# Altium schematic sheet Power Amplifier.SchDoc
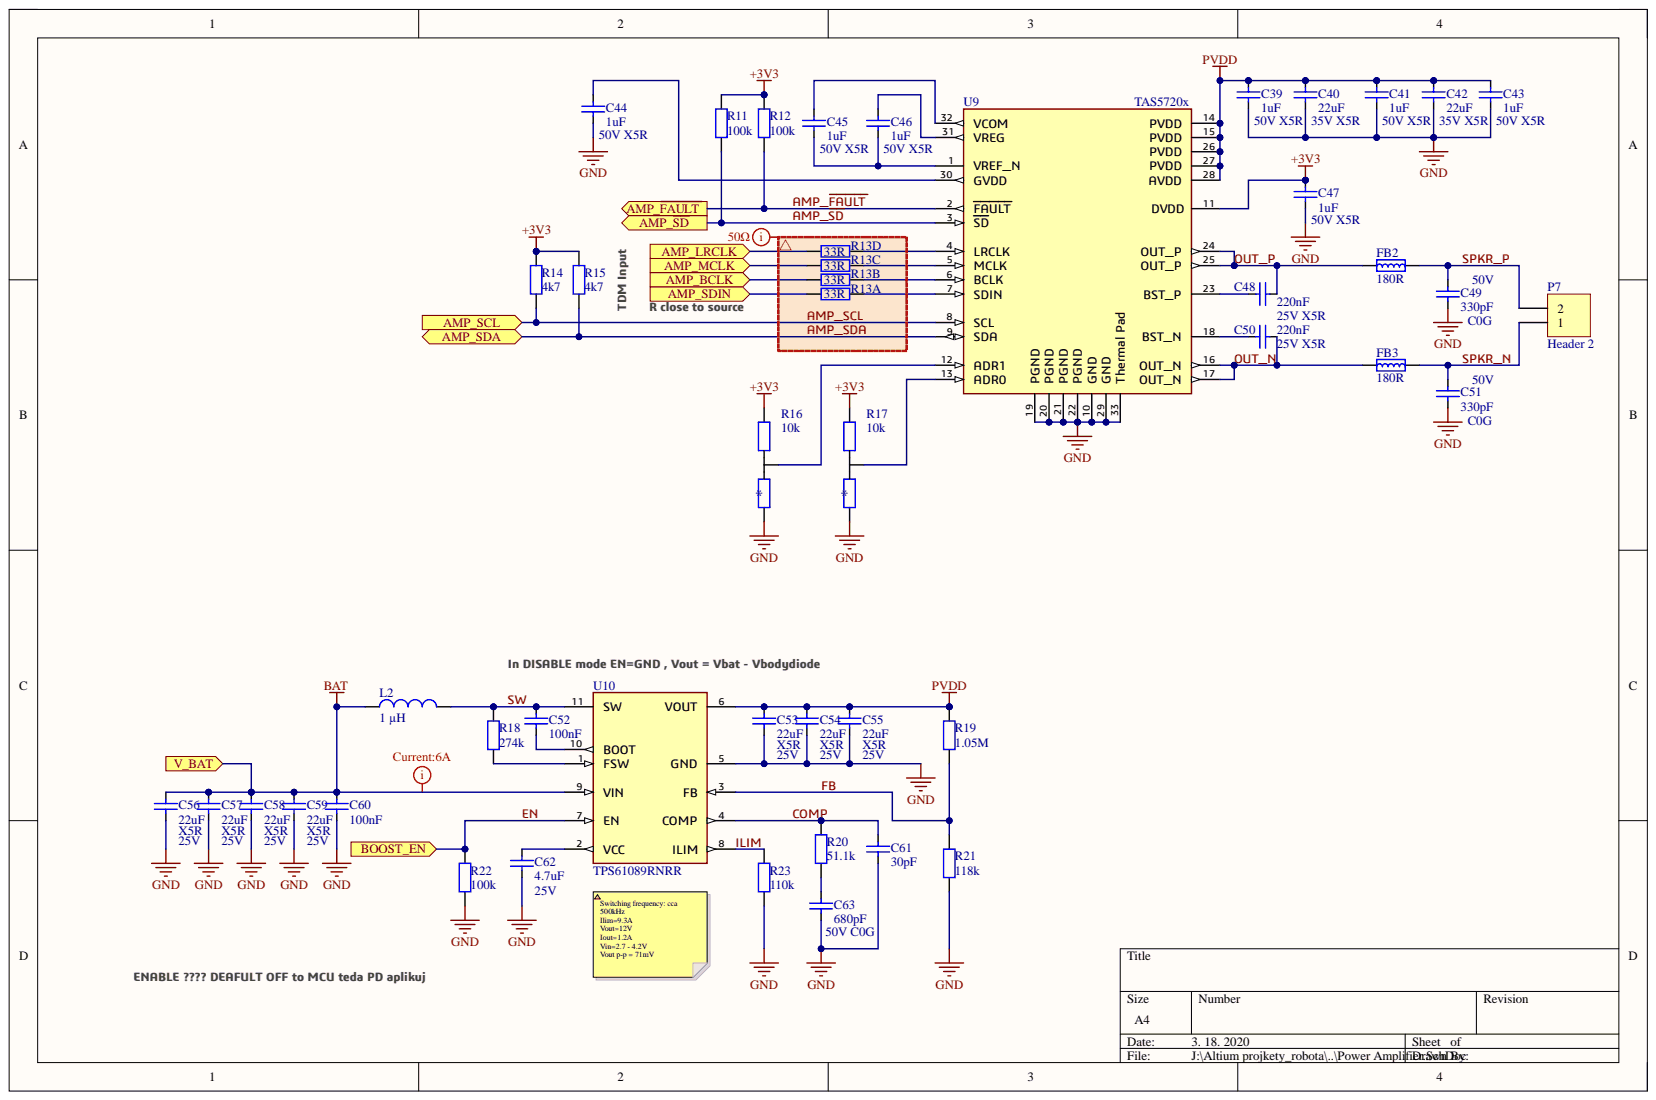
[diagram p0: Power Amplifier.SchDoc]

## Parts
C39  1uF  Voltage Rating (DC): 50V  [CAPACITOR_0603_(1608)]
C40  22uF  Voltage Rating: 35V X5R  Voltage Rating (DC): 35V  [CAPACITOR_1206_(3216)]
C41  1uF  Dielectric: X5R  Voltage Rating: 50V X5R  Voltage Rating (DC): 50V  [CAPACITOR_0603_(1608)]
C42  22uF  Voltage Rating: 35V X5R  Voltage Rating (DC): 35V  [CAPACITOR_1206_(3216)]
C43  1uF  Dielectric: X5R  Voltage Rating: 50V X5R  Voltage Rating (DC): 50V  [CAPACITOR_0603_(1608)]
C44  1uF  Dielectric: X5R  Voltage Rating: 50V X5R  Voltage Rating (DC): 50V  [CAPACITOR_0603_(1608)]
C45  1uF  Voltage Rating (DC): 50V  [CAPACITOR_0603_(1608)]
C46  1uF  Dielectric: X5R  Voltage Rating: 50V X5R  Voltage Rating (DC): 50V  [CAPACITOR_0603_(1608)]
C47  1uF  Dielectric: X5R  Voltage Rating: 50V X5R  Voltage Rating (DC): 50V  [CAPACITOR_0603_(1608)]
C48  220nF  Voltage Rating: 25V X5R  [CAPACITOR_0603_(1608)]
C49  330pF  Dielectric: C0G  Voltage Rating: 50V  [CAPACITOR_0603_(1608)]
C50  220nF  Voltage Rating: 25V X5R  [CAPACITOR_0603_(1608)]
C51  330pF  Dielectric: C0G  Voltage Rating: 50V  [CAPACITOR_0603_(1608)]
C52  100nF  [CAPACITOR_0603_(1608)]
C53  22uF  Dielectric: X5R  Voltage: 25V  [CAPACITOR_0805_(2012)]
C54  22uF  Dielectric: X5R  Voltage: 25V  [CAPACITOR_0805_(2012)]
C55  22uF  Dielectric: X5R  Voltage: 25V  [CAPACITOR_0805_(2012)]
C56  22uF  Dielectric: X5R  Voltage: 25V  [CAPACITOR_0805_(2012)]
C57  22uF  Dielectric: X5R  Voltage: 25V  [CAPACITOR_0805_(2012)]
C58  22uF  Dielectric: X5R  Voltage: 25V  [CAPACITOR_0805_(2012)]
C59  22uF  Dielectric: X5R  Voltage: 25V  [CAPACITOR_0805_(2012)]
C60  100nF  [CAPACITOR_0603_(1608)]
C61  30pF  [CAPACITOR_0603_(1608)]
C62  4.7uF  Voltage: 25V  [CAPACITOR_0603_(1608)]
C63  680pF  Dielectric: C0G  Voltage: 50V  Voltage Rating: 50V C0G  [CAPACITOR_0603_(1608)]
FB2  180R  [FERRITE BEAD_0806 (2016 metric)]
FB3  180R  [FERRITE BEAD_0806 (2016 metric)]
L2  1 µH  [Power Inductor_073x066 (SMD)]
P7  Header 2  [HDR1X2]
R11  100k  [RESISTOR_0603_(1608)]
R12  100k  [RESISTOR_0603_(1608)]
R13  33R  [RESISTOR_NETWORK_4x_0603_(1608)]  (parts A,B,C,D)
R14  4k7  [RESISTOR_0603_(1608)]
R15  4k7  [RESISTOR_0603_(1608)]
R16  10k  [R603-3pad]
R17  10k  [R603-3pad]
R18  274k  [RESISTOR_0603_(1608)]
R19  1.05M  [RESISTOR_0603_(1608)]
R20  51.1k  [RESISTOR_0603_(1608)]
R21  118k  [RESISTOR_0603_(1608)]
R22  100k  [RESISTOR_0603_(1608)]
R23  110k  [RESISTOR_0603_(1608)]
U9  TAS5720x  [VQFN32 4 x 4, 0.4 mm p]
U10  TPS61089RNRR  [VQFN_HR RNR0011A]

## Ports
AMP_BCLK, AMP_LRCLK, AMP_MCLK, AMP_SCL, AMP_SDA, AMP_SDIN, AMP_~{FAULT}, AMP_~{SD}, BOOST_EN, V_BAT

## Nets
+3V3: C47.1 R11.2 R12.2 R14.2 R15.2 R16.3 R17.3 U9.11(DVDD)
GND: C39.2 C40.2 C41.2 C42.2 C43.2 C44.2 C47.2 C49.1 C51.1 C53.1 C54.1 C55.1 C56.1 C57.1 C58.1 C59.1 C60.2 C61.1 C62.2 C63.1 R16.1 R17.1 R21.1 R22.1 R23.1 U9.10(GND) U9.19(PGND) U9.20(PGND) U9.21(PGND) U9.22(PGND) U9.29(GND) U9.33(Thermal_Pad) U10.5(GND)
PVDD: C39.1 C40.1 C41.1 C42.1 C43.1 C53.2 C54.2 C55.2 R19.2 U9.14(PVDD) U9.15(PVDD) U9.26(PVDD) U9.27(PVDD) U9.28(AVDD) U10.6(VOUT)
AMP_BCLK: R13.4 ; port AMP_BCLK
AMP_~{FAULT}: R12.1 U9.2(FAULT) ; port AMP_~{FAULT}
AMP_LRCLK: R13.8 ; port AMP_LRCLK
AMP_MCLK: R13.6 ; port AMP_MCLK
AMP_~{SD}: R11.1 U9.3(SD) ; port AMP_~{SD}
AMP_SCL: R14.1 U9.8(SCL) ; port AMP_SCL
AMP_SDA: R15.1 U9.9(SDA) ; port AMP_SDA
AMP_SDIN: R13.2 ; port AMP_SDIN
BAT: C56.2 C57.2 C58.2 C59.2 C60.1 L2.1 U10.9(VIN) ; port V_BAT
COMP: C61.2 R20.2 U10.4(COMP)
EN: R22.2 U10.7(EN) ; port BOOST_EN
FB: R19.1 R21.2 U10.3(FB)
ILIM: R23.2 U10.8(ILIM)
OUT_N: C50.2 FB3.1 U9.16(OUT_N) U9.17(OUT_N)
OUT_P: C48.2 FB2.1 U9.24(OUT_P) U9.25(OUT_P)
SPKR_N: C51.2 FB3.2 P7.1
SPKR_P: C49.2 FB2.2 P7.2
SW: C52.1 L2.2 R18.2 U10.11(SW)
NetC44_1: C44.1 U9.30(GVDD)
NetC45_1: C45.1 U9.32(VCOM)
NetC45_2: C45.2 C46.2 U9.1(VREF_N)
NetC46_1: C46.1 U9.31(VREG)
NetC48_1: C48.1 U9.23(BST_P)
NetC50_1: C50.1 U9.18(BST_N)
NetC52_2: C52.2 U10.10(BOOT)
NetC62_1: C62.1 U10.2(VCC)
NetC63_2: C63.2 R20.1
NetR13_1: R13.1 U9.7(SDIN)
NetR13_3: R13.3 U9.6(BCLK)
NetR13_5: R13.5 U9.5(MCLK)
NetR13_7: R13.7 U9.4(LRCLK)
NetR16_2: R16.2 U9.12(ADR1)
NetR17_2: R17.2 U9.13(ADR0)
NetR18_1: R18.1 U10.1(FSW)

## Library data of the parts
C39 C45 = CAPACITOR: Manufacturer 1: Taiyo Yuden; Manufacturer Part Number 1: UMK107BJ105KA-T; Dielectric: X5R; ESR: 0.28R; Tolerance: 10%; Voltage Rating: 50V X5R; Capacitance: 1uF; Case Code (Imperial): 0603; Case Code (Metric): 1608; Case/Package: 0603; Component Kind: Standard; ComponentLink1Description: https://datasheet.ciiva.com/5744/1697715-5744913.pdf?src-supplier=Farnell; ComponentLink1URL: https://datasheet.ciiva.com/5744/1697715-5744913.pdf?src-supplier=Farnell; ComponentLink2Description: https://datasheet.ciiva.com/11601/umk107bj105ka-t-ss-11601811.pdf?src-supplier=Avnet; ComponentLink2URL: https://datasheet.ciiva.com/11601/umk107bj105ka-t-ss-11601811.pdf?src-supplier=Avnet; ComponentLink3Description: https://datasheet.ciiva.com/15922/336umk107bj105ka-t-15922611.pdf?src-supplier=Onlinecomponents.com; ComponentLink3URL: https://datasheet.ciiva.com/15922/336umk107bj105ka-t-15922611.pdf?src-supplier=Onlinecomponents.com; ComponentLink4Description: https://datasheet.ciiva.com/134/umk107bj105ka-t-ss-134740.pdf?src-supplier=Digi-Key; ComponentLink4URL: https://datasheet.ciiva.com/134/umk107bj105ka-t-ss-134740.pdf?src-supplier=Digi-Key; ComponentLink5Description: https://datasheet.ciiva.com/30199/43umk107bj105ka-t-30199791.pdf?src-supplier=Onlinecomponents.com; ComponentLink5URL: https://datasheet.ciiva.com/30199/43umk107bj105ka-t-30199791.pdf?src-supplier=Onlinecomponents.com; ComponentLink6Description: https://datasheet.ciiva.com/22694/1697715-22694278.pdf?src-supplier=Element14; ComponentLink6URL: https://datasheet.ciiva.com/22694/1697715-22694278.pdf?src-supplier=Element14; ComponentLink7Description: https://datasheet.ciiva.com/7975/1697715-7975608.pdf?src-supplier=Element14; ComponentLink7URL: https://datasheet.ciiva.com/7975/1697715-7975608.pdf?src-supplier=Element14; ComponentLink8Description: https://datasheet.ciiva.com/7975/mlcc-all-e-7975898.pdf?src-supplier=Element14; ComponentLink8URL: https://datasheet.ciiva.com/7975/mlcc-all-e-7975898.pdf?src-supplier=Element14; ComponentLink9Description: https://datasheet.ciiva.com/5427/mlcc-all-e-541559-5427036.pdf?src-supplier=Mouser; ComponentLink9URL: https://datasheet.ciiva.com/5427/mlcc-all-e-541559-5427036.pdf?src-supplier=Mouser; Contact Plating: Nickel; Depth: 0.8mm; Description: CAPACITOR; Halogen Free: Halogen Free; Height: 0.8mm; Length: 1.6mm; Max Operating Temperature: 85°C; Min Operating Temperature: -55°C; Mount: Surface Mount; Number of Pins: 2; Package Quantity: 1; Packaging: Tape and Reel; Pricing 1: 10=0,14300, 100=0,06560, 500=0,04260, 1000=0,03940, 2000=0,03750, 4000=0,02830, 20000=0,02630 (EUR); Radiation Hardening: No; REACH SVHC: Unknown; RoHS: Yes; Stock 1: 372983; Supplier 1: Farnell; Supplier Part Number 1: 2112858; Termination: SMD/SMT; Thickness: 0.035inch; Width: 0.031inch; Library Name: RLCFB.SchLib
C40 C42 = CAPACITOR: Manufacturer 1: TDK; Manufacturer Part Number 1: C3216JB1V226M160AC; Dielectric Material: Ceramic; ESR: 0.28R; Tolerance: 20%; Capacitance: 22uF; Case Code (Imperial): 1206; Case Code (Metric): 3216; Case/Package: 1206; Component Kind: Standard; ComponentLink1Description: http://www.mouser.com/ds/2/400/lcc_commercial_general_en-837201.pdf?src-supplier=Mouser; ComponentLink1URL: http://www.mouser.com/ds/2/400/lcc_commercial_general_en-837201.pdf?src-supplier=Mouser; ComponentLink2Description: https://product.tdk.com/info/en/catalog/spec/mlccspec_commercial_general_midvoltage_en.pdf?src-supplier=Digi-Key; ComponentLink2URL: https://product.tdk.com/info/en/catalog/spec/mlccspec_commercial_general_midvoltage_en.pdf?src-supplier=Digi-Key; ComponentLink3Description: http://product.tdk.com/en/catalog/datasheets/mlcc_commercial_general_en.pdf?src-supplier=Avnet; ComponentLink3URL: http://product.tdk.com/en/catalog/datasheets/mlcc_commercial_general_en.pdf?src-supplier=Avnet; Composition: Ceramic; Description: CAPACITOR; Height: 1.6mm; Lead Free: Lead Free; Length: 0.126inch; Max Operating Temperature: 85°C; Min Operating Temperature: -25°C; Mount: Surface Mount; Package Quantity: 2000; Packaging: Tape and Reel; Pricing 1: 1=1,12000, 10=0,84700, 50=0,84700, 100=0,59600, 1000=0,45200, 10000=0,28700 (USD); RoHS: Yes; Stock 1: 128007; Supplier 1: Mouser; Supplier Part Number 1: 810-C3216JB1V226M16C; Termination: SMD/SMT; Thickness: 0.069inch; Width: 0.063inch; Library Name: RLCFB.SchLib
C41 C43 C44 C46 C47 = CAPACITOR: Manufacturer 1: Taiyo Yuden; Manufacturer Part Number 1: UMK107BJ105KA-T; ESR: 0.28R; Tolerance: 10%; Capacitance: 1uF; Case Code (Imperial): 0603; Case Code (Metric): 1608; Case/Package: 0603; Component Kind: Standard; ComponentLink1Description: https://datasheet.ciiva.com/5744/1697715-5744913.pdf?src-supplier=Farnell; ComponentLink1URL: https://datasheet.ciiva.com/5744/1697715-5744913.pdf?src-supplier=Farnell; ComponentLink2Description: https://datasheet.ciiva.com/11601/umk107bj105ka-t-ss-11601811.pdf?src-supplier=Avnet; ComponentLink2URL: https://datasheet.ciiva.com/11601/umk107bj105ka-t-ss-11601811.pdf?src-supplier=Avnet; ComponentLink3Description: https://datasheet.ciiva.com/15922/336umk107bj105ka-t-15922611.pdf?src-supplier=Onlinecomponents.com; ComponentLink3URL: https://datasheet.ciiva.com/15922/336umk107bj105ka-t-15922611.pdf?src-supplier=Onlinecomponents.com; ComponentLink4Description: https://datasheet.ciiva.com/134/umk107bj105ka-t-ss-134740.pdf?src-supplier=Digi-Key; ComponentLink4URL: https://datasheet.ciiva.com/134/umk107bj105ka-t-ss-134740.pdf?src-supplier=Digi-Key; ComponentLink5Description: https://datasheet.ciiva.com/30199/43umk107bj105ka-t-30199791.pdf?src-supplier=Onlinecomponents.com; ComponentLink5URL: https://datasheet.ciiva.com/30199/43umk107bj105ka-t-30199791.pdf?src-supplier=Onlinecomponents.com; ComponentLink6Description: https://datasheet.ciiva.com/22694/1697715-22694278.pdf?src-supplier=Element14; ComponentLink6URL: https://datasheet.ciiva.com/22694/1697715-22694278.pdf?src-supplier=Element14; ComponentLink7Description: https://datasheet.ciiva.com/7975/1697715-7975608.pdf?src-supplier=Element14; ComponentLink7URL: https://datasheet.ciiva.com/7975/1697715-7975608.pdf?src-supplier=Element14; ComponentLink8Description: https://datasheet.ciiva.com/7975/mlcc-all-e-7975898.pdf?src-supplier=Element14; ComponentLink8URL: https://datasheet.ciiva.com/7975/mlcc-all-e-7975898.pdf?src-supplier=Element14; ComponentLink9Description: https://datasheet.ciiva.com/5427/mlcc-all-e-541559-5427036.pdf?src-supplier=Mouser; ComponentLink9URL: https://datasheet.ciiva.com/5427/mlcc-all-e-541559-5427036.pdf?src-supplier=Mouser; Contact Plating: Nickel; Depth: 0.8mm; Description: CAPACITOR; Halogen Free: Halogen Free; Height: 0.8mm; Length: 1.6mm; Max Operating Temperature: 85°C; Min Operating Temperature: -55°C; Mount: Surface Mount; Number of Pins: 2; Package Quantity: 1; Packaging: Tape and Reel; Pricing 1: 10=0,14300, 100=0,06560, 500=0,04260, 1000=0,03940, 2000=0,03750, 4000=0,02830, 20000=0,02630 (EUR); Radiation Hardening: No; REACH SVHC: Unknown; RoHS: Yes; Stock 1: 372983; Supplier 1: Farnell; Supplier Part Number 1: 2112858; Termination: SMD/SMT; Thickness: 0.035inch; Width: 0.031inch; Library Name: RLCFB.SchLib
C48 C50 = CAPACITOR: ESR: 0.28R; Capacitance: 220nF; Component Kind: Standard; Description: CAPACITOR; Library Name: RLCFB.SchLib
C49 C51 = CAPACITOR: Manufacturer 1: Kyocera AVX; Manufacturer Part Number 1: 06035A331FAT2A; Tolerance: 1%; Capacitance: 330pF; Case Code (Imperial): 0603; Case Code (Metric): 1608; Case/Package: 0603; Component Kind: Standard; ComponentLink1Description: https://datasheet.ciiva.com/152/ccog-152848.pdf?src-supplier=Digi-Key; ComponentLink1URL: https://datasheet.ciiva.com/152/ccog-152848.pdf?src-supplier=Digi-Key; ComponentLink2Description: https://datasheet.ciiva.com/152/ccog-152849.pdf?src-supplier=Digi-Key; ComponentLink2URL: https://datasheet.ciiva.com/152/ccog-152849.pdf?src-supplier=Digi-Key; ComponentLink3Description: https://datasheet.ciiva.com/152/ccog-152850.pdf?src-supplier=Digi-Key; ComponentLink3URL: https://datasheet.ciiva.com/152/ccog-152850.pdf?src-supplier=Digi-Key; ComponentLink4Description: https://datasheet.ciiva.com/22677/1796416-22677029.pdf?src-supplier=Element14; ComponentLink4URL: https://datasheet.ciiva.com/22677/1796416-22677029.pdf?src-supplier=Element14; ComponentLink5Description: https://datasheet.ciiva.com/5415/ccog-243403-5415239.pdf?src-supplier=Mouser; ComponentLink5URL: https://datasheet.ciiva.com/5415/ccog-243403-5415239.pdf?src-supplier=Mouser; ComponentLink6Description: https://datasheet.ciiva.com/17491/ccog-17491576.pdf?src-supplier=Avnet+Europe; ComponentLink6URL: https://datasheet.ciiva.com/17491/ccog-17491576.pdf?src-supplier=Avnet+Europe; Contact Plating: Tin,Matte; Depth: 0.81mm; Description: CAPACITOR; Height: 0.63mm; Lead Free: Lead Free; Length: 1.6mm; Max Operating Temperature: 125°C; Min Operating Temperature: -55°C; Mount: Surface Mount; Number of Pins: 2; Package Quantity: 1; Packaging: Tape and Reel; Pricing 1: 10=0,38200, 100=0,17000, 500=0,12600, 1000=0,10600, 2000=0,09380, 4000=0,07570, 20000=0,06650 (EUR); Radiation Hardening: No; REACH SVHC: No SVHC; RoHS: Yes; Stock 1: 36265; Supplier 1: Farnell; Supplier Part Number 1: 2332616; Termination: SMD/SMT; Thickness: 0.035inch; Voltage Rating (DC): 50V; Width: 0.032inch; Library Name: RLCFB.SchLib
C52 C60 = CAPACITOR: Manufacturer 1: Multicomp; Manufacturer Part Number 1: MC0603B104K500CT; Dielectric: X7R; Tolerance: 10%; Voltage Rating: 50V; Capacitance: 100nF; Case Code (Imperial): 0603; Case Code (Metric): 1608; Case/Package: 0603; Component Kind: Standard; ComponentLink1Description: https://datasheet.ciiva.com/22686/1901289-22686278.pdf?src-supplier=Element14; ComponentLink1URL: https://datasheet.ciiva.com/22686/1901289-22686278.pdf?src-supplier=Element14; ComponentLink2Description: https://datasheet.ciiva.com/22686/1901289-22686279.pdf?src-supplier=Element14; ComponentLink2URL: https://datasheet.ciiva.com/22686/1901289-22686279.pdf?src-supplier=Element14; ComponentLink3Description: https://datasheet.ciiva.com/22648/1901289-22648411.pdf?src-supplier=Element14; ComponentLink3URL: https://datasheet.ciiva.com/22648/1901289-22648411.pdf?src-supplier=Element14; Description: CAPACITOR; Max Operating Temperature: 125°C; Min Operating Temperature: -55°C; Number of Pins: 2; Packaging: Cut Tape; Pricing 1: 10=0,06930, 100=0,03990, 500=0,02750, 1000=0,02270, 2000=0,01940 (GBP); REACH SVHC: Unknown; RoHS: Yes; Stock 1: 1128297; Supplier 1: Farnell; Supplier Part Number 1: 1759122; Voltage Rating (DC): 50V; Library Name: RLCFB.SchLib
C53-C59 = CAPACITOR: Manufacturer 1: Murata; Manufacturer Part Number 1: GRM21BR61E226ME44L; Material: Ceramic; Tolerance: 20%; Capacitance: 22uF; Case Code (Imperial): 0805; Case Code (Metric): 2012; Case/Package: 0805; Component Kind: Standard; ComponentLink1Description: https://datasheet.ciiva.com/22787/1747471-22787265.pdf?src-supplier=Farnell; ComponentLink1URL: https://datasheet.ciiva.com/22787/1747471-22787265.pdf?src-supplier=Farnell; ComponentLink2Description: https://datasheet.ciiva.com/7719/1747471-7719095.pdf?src-supplier=Element14; ComponentLink2URL: https://datasheet.ciiva.com/7719/1747471-7719095.pdf?src-supplier=Element14; ComponentLink3Description: https://datasheet.ciiva.com/28584/1747471-28584199.pdf?src-supplier=Element14; ComponentLink3URL: https://datasheet.ciiva.com/28584/1747471-28584199.pdf?src-supplier=Element14; Composition: Ceramic; Depth: 1.25mm; Description: CAPACITOR; Height: 1.25mm; Lead Free: Lead Free; Length: 2mm; Max Operating Temperature: 85°C; Min Operating Temperature: -55°C; Mount: Surface Mount; Package Quantity: 3000; Packaging: Tape and Reel; Pricing 1: 5=0,42800, 50=0,25100, 250=0,20300, 500=0,15000, 1500=0,12800 (EUR); REACH SVHC: No SVHC; RoHS: Yes; Stock 1: 70400; Supplier 1: Farnell; Supplier Part Number 1: 1907510; Termination: SMD/SMT; Thickness: 0.057inch; Voltage Rating: 25V; Voltage Rating (DC): 25V; Weight: 0.000335oz; Width: 1.25mm; Library Name: RLCFB.SchLib
C61 = CAPACITOR: ESR: 0.28R; Voltage Rating: 10V; Capacitance: 30pF; Component Kind: Standard; Description: CAPACITOR; Library Name: RLCFB.SchLib
C62 = CAPACITOR: Manufacturer 1: Murata; Manufacturer Part Number 1: GRM188R61E475KE11D; Dielectric: X5R; ESR: 0.28R; Material: Ceramic; Tolerance: 10%; Capacitance: 4.7uF; Case Code (Imperial): 0603; Case Code (Metric): 1608; Case/Package: 0603; Component Kind: Standard; ComponentLink1Description: https://datasheet.ciiva.com/46444/1769893-46444982.pdf?src-supplier=Element14; ComponentLink1URL: https://datasheet.ciiva.com/46444/1769893-46444982.pdf?src-supplier=Element14; ComponentLink2Description: https://datasheet.ciiva.com/46444/1769893-46444983.pdf?src-supplier=Element14; ComponentLink2URL: https://datasheet.ciiva.com/46444/1769893-46444983.pdf?src-supplier=Element14; ComponentLink3Description: https://datasheet.ciiva.com/14891/partnumbering-e-01-14891102.pdf?src-supplier=Digi-Key; ComponentLink3URL: https://datasheet.ciiva.com/14891/partnumbering-e-01-14891102.pdf?src-supplier=Digi-Key; ComponentLink4Description: https://datasheet.ciiva.com/14891/partnumbering-e-01-14891103.pdf?src-supplier=Digi-Key; ComponentLink4URL: https://datasheet.ciiva.com/14891/partnumbering-e-01-14891103.pdf?src-supplier=Digi-Key; Depth: 0.8mm; Description: CAPACITOR; Height: 0.8mm; Lead Free: Lead Free; Length: 1.6mm; Max Operating Temperature: 85°C; Min Operating Temperature: -55°C; Mount: Surface Mount; Package Quantity: 4000; Packaging: Cut Tape; Pricing 1: 10=0,35700, 100=100,00000, 500=0,15900, 1000=0,12800, 2000=0,10200 (CHF); REACH SVHC: No SVHC; RoHS: Yes; Stock 1: 95119; Supplier 1: Farnell; Supplier Part Number 1: 2426959; Thickness: 0.031inch; Voltage Rating: 25V; Voltage Rating (DC): 25V; Width: 0.031inch; Library Name: RLCFB.SchLib
C63 = CAPACITOR: Manufacturer 1: KEMET; Manufacturer Part Number 1: C0603C681J5GACTU; Dielectric Material: Ceramic; Dissipation Factor: 0.1%; ESR: 0.28R; Temperature Coefficient: 30ppm/°C; Tolerance: 5%; Capacitance: 680pF; Case Code (Imperial): 0603; Case Code (Metric): 1608; Case/Package: 0603; Component Kind: Standard; ComponentLink1Description: https://datasheet.ciiva.com/144/docfinderpartnumber-c0603c681j5gactu-144808.pdf?src-supplier=Digi-Key; ComponentLink1URL: https://datasheet.ciiva.com/144/docfinderpartnumber-c0603c681j5gactu-144808.pdf?src-supplier=Digi-Key; ComponentLink2Description: https://datasheet.ciiva.com/28535/1372242-28535863.pdf?src-supplier=Element14; ComponentLink2URL: https://datasheet.ciiva.com/28535/1372242-28535863.pdf?src-supplier=Element14; ComponentLink3Description: https://datasheet.ciiva.com/7823/40889-7823833.pdf?src-supplier=Element14; ComponentLink3URL: https://datasheet.ciiva.com/7823/40889-7823833.pdf?src-supplier=Element14; ComponentLink4Description: https://datasheet.ciiva.com/7823/40889-7823834.pdf?src-supplier=Element14; ComponentLink4URL: https://datasheet.ciiva.com/7823/40889-7823834.pdf?src-supplier=Element14; ComponentLink5Description: https://datasheet.ciiva.com/14600/70095383-14600026.pdf?src-supplier=Allied+Electronics; ComponentLink5URL: https://datasheet.ciiva.com/14600/70095383-14600026.pdf?src-supplier=Allied+Electronics; ComponentLink6Description: https://datasheet.ciiva.com/11634/kem-c1003-c0g-smd-11634445.pdf?src-supplier=Avnet; ComponentLink6URL: https://datasheet.ciiva.com/11634/kem-c1003-c0g-smd-11634445.pdf?src-supplier=Avnet; Contact Plating: Tin,Matte; Depth: 0.8mm; Description: CAPACITOR; Height: 0.8mm; Lead Free: Lead Free; Lead Pitch: 0.7mm; Length: 1.6mm; Max Operating Temperature: 125°C; Min Operating Temperature: -55°C; Mount: Surface Mount,PCB; Number of Pins: 2; Package Quantity: 4000; Packaging: Tape and Reel; Pricing 1: 10=0,05930, 100=0,04080, 500=0,02740, 1000=0,02050, 2000=0,01880 (EUR); Radiation Hardening: No; REACH SVHC: No SVHC; RoHS: Yes; Stock 1: 20174; Supplier 1: Farnell; Supplier Part Number 1: 1414648; Termination: SMD/SMT; Thickness: 0.034inch; Voltage Rating (DC): 50V; Width: 0.8mm; Library Name: RLCFB.SchLib
FB2 FB3 = FERRITE_BEAD: Manufacturer 1: Murata; Manufacturer Part Number 1: NFZ2MSM181SN10L; DC Resistance (DCR): 25mR; DC Resistance Max: 100R; Max Current Rating: 3.4A; Number of Lines: 1; Case Code (Imperial): 0806; Case Code (Metric): 2016; Case/Package: 0806; Component Kind: Standard; ComponentLink1Description: https://www.murata.com/en-us/products/productdata/8796736815134/ENFJ0002.pdf?src-supplier=Digi-Key; ComponentLink1URL: https://www.murata.com/en-us/products/productdata/8796736815134/ENFJ0002.pdf?src-supplier=Digi-Key; Description: FERRITE_BEAD; Impedance@100MHz: 180R; Max Operating Temperature: 85°C; Min Operating Temperature: -40°C; Mount: Surface Mount; Packaging: Tape and Reel; Pricing 1: 1=0,37000, 10=0,23000, 100=0,20000, 250=0,17700, 500=0,16000, 1000=0,13600 (USD); RoHS: No; Stock 1: 8719; Supplier 1: Mouser; Supplier Part Number 1: 81-NFZ2MSM181SN10L; Library Name: RLCFB.SchLib
L2 = INDUCTOR: INDUCTOR_High_Frequency; Manufacturer: Bourns; Manufacturer Part Number: SRP7028A-1R0M; Core Material: Ferrite; Current Rating: 11A; DC Resistance (DCR): 10mR; DC Resistance Max: 0,01ohm; Inductance Tolerance: 20%; Q Factor: 20; Saturation Current: 22A; Self Resonant Frequency: 58MHz; Shielding: Shielded; Test Frequency: 100kHz; Case/Package: Nonstandard; Component Kind: Standard; ComponentLink1Description: https://datasheet.ciiva.com/46385/1828826-46385115.pdf?src-supplier=Element14; ComponentLink1URL: https://datasheet.ciiva.com/46385/1828826-46385115.pdf?src-supplier=Element14; ComponentLink2Description: https://datasheet.ciiva.com/48685/srp7028a-48685356.pdf?src-supplier=Avnet; ComponentLink2URL: https://datasheet.ciiva.com/48685/srp7028a-48685356.pdf?src-supplier=Avnet; ComponentLink3Description: https://datasheet.ciiva.com/48685/srp7028a-48685359.pdf?src-supplier=Avnet; ComponentLink3URL: https://datasheet.ciiva.com/48685/srp7028a-48685359.pdf?src-supplier=Avnet; Composition: Wirewound; Contact Plating: Tin; DC Current: 11A; DC Current Rating: 11A; Depth: 6.6mm; Height: 2.8mm; Height - Seated (Max): 0.118inch; Inductance: 1 µH; Length: 7.3mm; Max DC Current: 11A; Max Operating Temperature: 150°C; Military Standard: Not; Min Operating Temperature: -40°C; Mount: Surface Mount; Package Quantity: 1000; Packaging: Tape and Reel; Pricing 1: 1=1,47000, 50=0,85200, 100=0,75000, 250=0,69400, 500=0,64700, 1000=0,61500, 5000=0,58300 (EUR); Radiation Hardening: No; Ratings: AEC-Q200; REACH SVHC: No SVHC; RMS Current (Irms): 11A; RoHS: Yes; Stock 1: 1615; Supplier 1: Farnell; Supplier Part Number 1: 2434094; Termination: SMD/SMT; Tolerance: 20%; Width: 6.6mm; Library Name: RLCFB.SchLib
P7 = Header 2: Header, 2-Pin; Component Kind: Standard; LatestRevisionDate: 17-Jul-2002; LatestRevisionNote: Re-released for DXP Platform.; Library Name: Miscellaneous Connectors.IntLib; Signal Integrity: Connector; Publisher: Altium Limited
R11 R12 R14 R15 R18-R23 = RESISTOR: Power Rating: 500mW; Component Kind: Standard; Description: RESISTOR; Library Name: RLCFB.SchLib
  R11 R12 R22: Resistance: 100k
  R14 R15: Resistance: 4k7
  R18: Resistance: 274k
  R19: Resistance: 1.05M
  R20: Resistance: 51.1k
  R21: Resistance: 118k
  R23: Resistance: 110k
R13 = RESISTOR Network 4 Elements, Isolated, 0603, 33R: EXBV8V330JV -  Fixed Network Resistor, 33 ohm, EXBV Seies, 4 Elements, Isolated, 0603 [1608 Metric], 8 Pins; Manufacturer 1: Panasonic; Manufacturer Part Number 1: EXB-V8V330JV; Number of Contacts: 8; Number of Elements: 4; Power Dissipation: 63mW; Power Per Element: 62.5mW; Temperature Coefficient: 200ppm/°C; Tolerance: 5%; Voltage Rating: 50V; Voltage Rating (DC): 100V; Case Code (Imperial): 0603; Case Code (Metric): 1608; Case/Package: 0603; Circuit Type: Isolated; Component Kind: Standard; Contact Plating: Tin; Depth: 1.6mm; Height: 0.028inch; Lead Free: Lead Free; Length: 3.2mm; Library Name: Source.SchLib; Max Operating Temperature: 125°C; Military Standard: Not; Min Operating Temperature: -55°C; Mount: Surface Mount; Number of Pins: 8; Number of Resistors: 4; Number of Terminals: 8; Package Quantity: 1; Packaging: Tape and Reel; Pricing 1: 10=0,05970, 100=0,04480, 500=0,03590, 1000=0,03170, 2500=0,02440, 5000=0,02030, 25000=0,01610 (EUR); Radiation Hardening: No; REACH SVHC: No SVHC; Resistance: 33R; RoHS: Yes; Stock 1: 1324; Supplier 1: Farnell; Supplier Part Number 1: 2060153; Terminal Pitch: 0.8mm; Termination: Concave; Thickness: 600um; Width: 0.063inch
R16 R17 = R_PULL: Pull up or pull down resistor; Component Kind: Standard; Library Name: BB1_SCH_Library.SchLib
U9 = TAS5720L  I2S AMP: TAS5720x Digital Input Mono Class-D Audio Amplifier  With TDM Support Up To 8 Channels; Manufacturer 1: Texas Instruments; Manufacturer Part Number 1: TAS5720LRSMT; Max Output Power: 20W; Case/Package: VQFN; Component Kind: Standard; ComponentLink1Description: http://www.ti.com/lit/gpn/tas5720l?src-supplier=Mouser; ComponentLink1URL: http://www.ti.com/lit/gpn/tas5720l?src-supplier=Mouser; ComponentLink2Description: http://www.ti.com/lit/ds/symlink/tas5720l.pdf?src-supplier=Digi-Key; ComponentLink2URL: http://www.ti.com/lit/ds/symlink/tas5720l.pdf?src-supplier=Digi-Key; Lead Free: Lead Free; Library Name: BB1_SCH_Library.SchLib; Max Operating Temperature: 85°C; Min Operating Temperature: -25°C; Mount: Surface Mount; Packaging: Tape and Reel; Pricing 1: 1=4,07000, 10=3,66000, 100=2,99000, 250=2,81000, 500=2,55000, 1000=2,15000 (USD); RoHS: Yes; Stock 1: 421; Supplier 1: Mouser; Supplier Part Number 1: 595-TAS5720LRSMT
U10 = TPS61089RNRR: Switching Voltage Regulators; Manufacturer 1: Texas Instruments; Max Input Voltage: 12V; Max Output Voltage: 12.6V; Min Input Voltage: 2.7V; Min Output Voltage: 4.5V; Number of Outputs: 1; Output Configuration: Positive; Output Current: 7A; Output Type: Adjustable; Quiescent Current: 10uA; Switching Frequency: 2.2MHz; Synchronous Rectifier: Yes; Topology: Boost; Case/Package: VQFN; Component Kind: Standard; ComponentLink1Description: http://www.ti.com/lit/ds/symlink/tps61089.pdf?src-supplier=Digi-Key; ComponentLink1URL: http://www.ti.com/lit/ds/symlink/tps61089.pdf?src-supplier=Digi-Key; ComponentLink2Description: http://www.ti.com/general/docs/suppproductinfo.tsp?distId=10&amp;gotoUrl=http%3A%2F%2Fwww.ti.com%2Flit%2Fgpn%2Ftps61089&src-supplier=Digi-Key; ComponentLink2URL: http://www.ti.com/general/docs/suppproductinfo.tsp?distId=10&amp;gotoUrl=http%3A%2F%2Fwww.ti.com%2Flit%2Fgpn%2Ftps61089&src-supplier=Digi-Key; Lead Free: Lead Free; Library Name: BB1_SCH_Library.SchLib; Manufacturer Part Number 1: TPS61089RNRR; Max Operating Temperature: 85°C; Min Operating Temperature: -40°C; Mount: Surface Mount; Number of Pins: 11; Packaging: Tape and Reel; Pricing 1: 1=2,85000, 10=2,42000, 100=1,94000, 500=1,70000, 1000=1,41000, 3000=1,31000, 6000=1,26000, 9000=1,21000 (USD); RoHS: Yes; Stock 1: 7016; Supplier 1: Mouser; Supplier Part Number 1: 595-TPS61089RNRR
